# Altium schematic sheet print uno nano.SchDoc
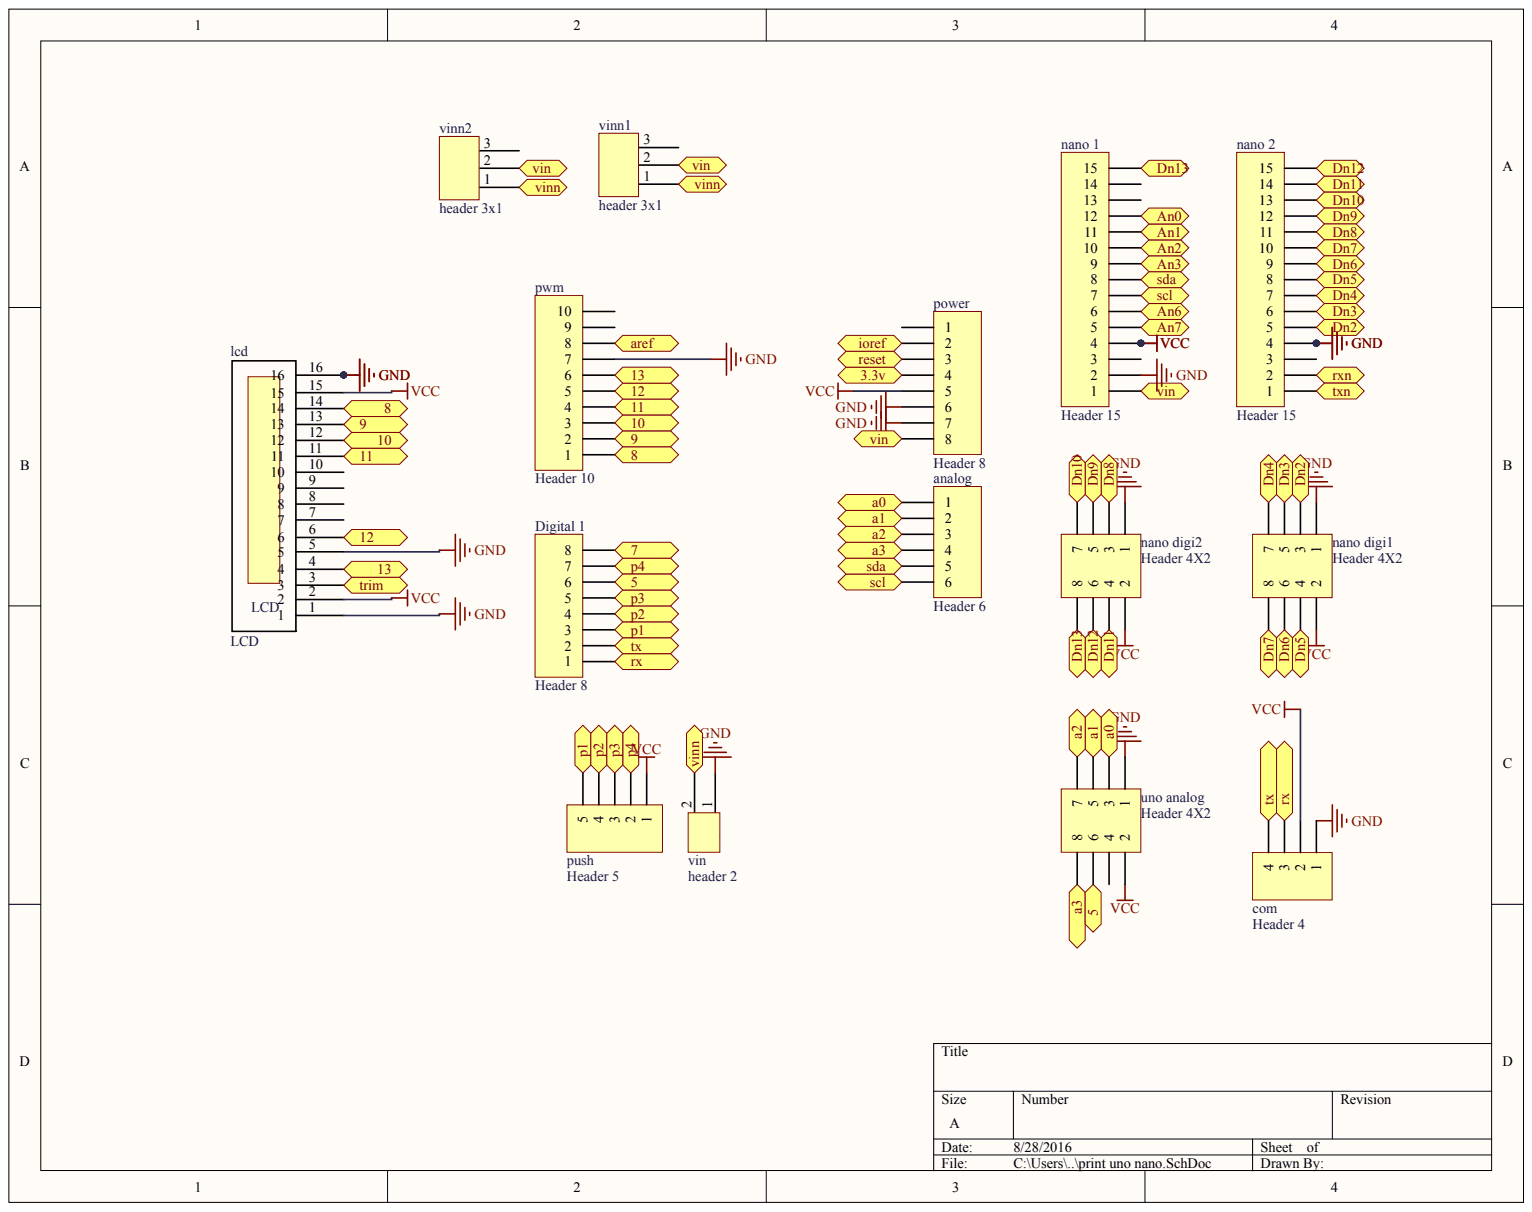
[diagram p0: print uno nano.SchDoc]

## Parts
analog  Header 6
com  Header 4
Digital_1  Header 8
lcd  LCD
nano_1  Header 15
nano_2  Header 15
nano_digi1  Header 4X2
nano_digi2  Header 4X2
power  Header 8
push  Header 5
pwm  Header 10
uno_analog  Header 4X2
vin  header 2
vinn1  header 3x1
vinn2  header 3x1

## Ports
3.3V, 5, 7, 8, 9, 10, 11, 12, 13, A0, A1, A2, A3, AN0, AN1, AN2, AN3, AN6, AN7, AREF, DN2, DN3, DN4, DN5, DN6, DN7, DN8, DN9, DN10, DN11, DN12, DN13, IOREF, P1, P2, P3, P4, RESET, RX, RXN, SCL, SDA, TRIM, TX, TXN, VIN, VINN

## Nets
GND: com.1 lcd.1 lcd.5 lcd.16 nano_1.2 nano_2.4 nano_digi1.1 nano_digi2.1 power.6 power.7 pwm.7 uno_analog.1 vin.1
VCC: com.2 lcd.2 lcd.15 nano_1.4 nano_digi1.2 nano_digi2.2 power.5 push.1 uno_analog.2
5: Digital_1.6 uno_analog.6 ; port 5
7: Digital_1.8 ; port 7
8: lcd.14 pwm.1 ; port 8
9: lcd.13 pwm.2 ; port 9
10: lcd.12 pwm.3 ; port 10
11: lcd.11 pwm.4 ; port 11
12: lcd.6 pwm.5 ; port 12
13: lcd.4 pwm.6 ; port 13
Netanalog_1: analog.1 uno_analog.3 ; port A0
Netanalog_2: analog.2 uno_analog.5 ; port A1
Netanalog_3: analog.3 uno_analog.7 ; port A2
Netanalog_4: analog.4 uno_analog.8 ; port A3
Netanalog_5: analog.5 nano_1.8 ; port SDA
Netanalog_6: analog.6 nano_1.7 ; port SCL
Netcom_3: com.3 Digital_1.1 ; port RX
Netcom_4: com.4 Digital_1.2 ; port TX
NetDigital 1_3: Digital_1.3 push.5 ; port P1
NetDigital 1_4: Digital_1.4 push.4 ; port P2
NetDigital 1_5: Digital_1.5 push.3 ; port P3
NetDigital 1_7: Digital_1.7 push.2 ; port P4
Netlcd_3: lcd.3 ; port TRIM
Netnano 1_1: nano_1.1 power.8 vinn1.2 vinn2.2 ; port VIN
Netnano 1_5: nano_1.5 ; port AN7
Netnano 1_6: nano_1.6 ; port AN6
Netnano 1_9: nano_1.9 ; port AN3
Netnano 1_10: nano_1.10 ; port AN2
Netnano 1_11: nano_1.11 ; port AN1
Netnano 1_12: nano_1.12 ; port AN0
Netnano 1_15: nano_1.15 nano_digi2.8 ; port DN13
Netnano 2_1: nano_2.1 ; port TXN
Netnano 2_2: nano_2.2 ; port RXN
Netnano 2_5: nano_2.5 nano_digi1.3 ; port DN2
Netnano 2_6: nano_2.6 nano_digi1.5 ; port DN3
Netnano 2_7: nano_2.7 nano_digi1.7 ; port DN4
Netnano 2_8: nano_2.8 nano_digi1.4 ; port DN5
Netnano 2_9: nano_2.9 nano_digi1.6 ; port DN6
Netnano 2_10: nano_2.10 nano_digi1.8 ; port DN7
Netnano 2_11: nano_2.11 nano_digi2.3 ; port DN8
Netnano 2_12: nano_2.12 nano_digi2.5 ; port DN9
Netnano 2_13: nano_2.13 nano_digi2.7 ; port DN10
Netnano 2_14: nano_2.14 nano_digi2.4 ; port DN11
Netnano 2_15: nano_2.15 nano_digi2.6 ; port DN12
Netpower_2: power.2 ; port IOREF
Netpower_3: power.3 ; port RESET
Netpower_4: power.4 ; port 3.3V
Netpwm_8: pwm.8 ; port AREF
Netvin_2: vin.2 vinn1.1 vinn2.1 ; port VINN

Not connected: lcd 7 8 9 10; nano_1 3 13 14; nano_2 3; power 1; pwm 9 10; uno_analog 4; vinn1 3; vinn2 3

## Library data of the parts
analog com Digital_1 lcd nano_1 nano_2 nano_digi1 nano_digi2 power push pwm uno_analog vin vinn1 vinn2 = (no symbol name)
  analog com Digital_1 nano_1 nano_2 nano_digi1 nano_digi2 power push pwm uno_analog: LatestRevisionDate: 17-Jul-2002; LatestRevisionNote: Re-released for DXP Platform.; Publisher: Altium Limited
